# Altium schematic sheet 02.STM32F103VCT6.SchDoc
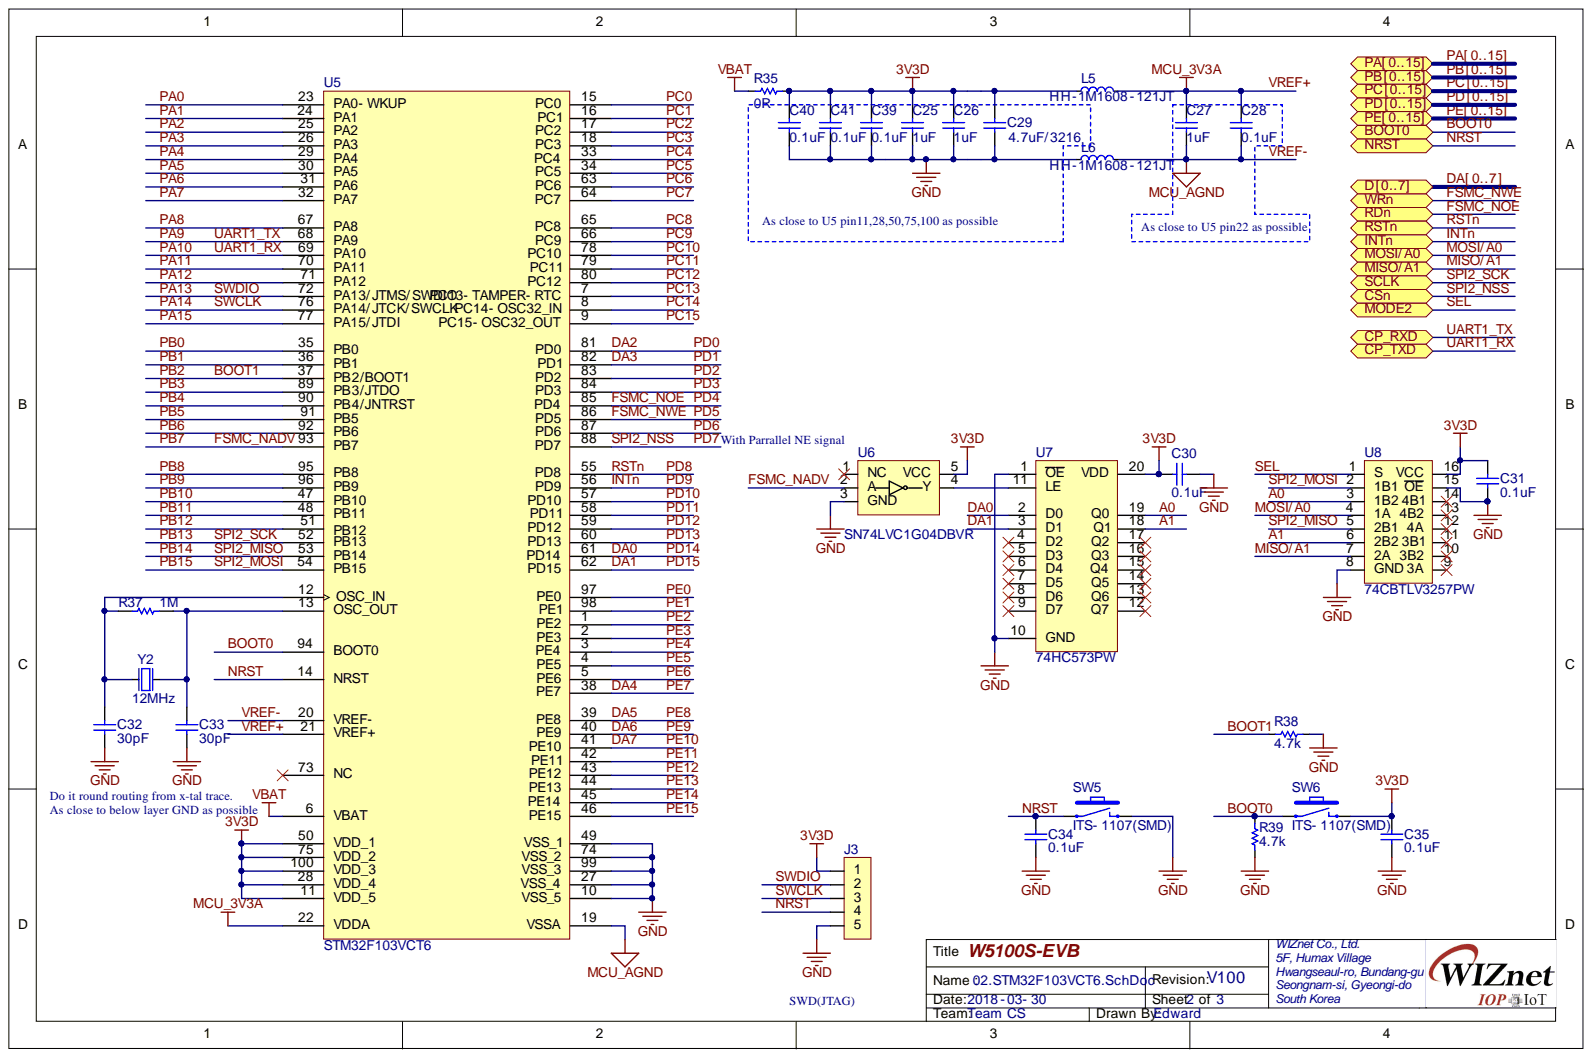
[diagram p0: 02.STM32F103VCT6.SchDoc]

## Parts
C25  1uF  [CAP-0603]
C26  1uF  [CAP-0603]
C27  1uF  [CAP-0603]
C28  0.1uF  [CAP-0603]
C29  4.7uF/3216  [CAP-1206]
C30  0.1uF  [CAP-0603]
C31  0.1uF  [CAP-0603]
C32  30pF  [CAP-0603]
C33  30pF  [CAP-0603]
C34  0.1uF  [CAP-0603]
C35  0.1uF  [CAP-0603]
C39  0.1uF  [CAP-0603]
C40  0.1uF  [CAP-0603]
C41  0.1uF  [CAP-0603]
J3  2.54mm 1x5 Pin Header DIP  [IDC-1X5]  (value not drawn)
L5  HH-1M1608-121JT  [IND-0603]
L6  HH-1M1608-121JT  [IND-0603]
R35  0R  [RES-0603]
R37  1M  [RES-0603]
R38  4.7k  [RES-0603]
R39  4.7k  [RES-0603]
SW5  ITS-1107(SMD)  [IT-1107(SMD)]
SW6  ITS-1107(SMD)  [IT-1107(SMD)]
U5  STM32F103VCT6  [LQFP100(0.5/16.0)]
U6  SN74LVC1G04DBVR  [SOT-23-5L]
U7  74HC573PW  [TSSOP20(0.65/6.4)]
U8  74CBTLV3257PW  [TSSOP16(0.65/6.4)]
Y2  12MHz  [OSC-82J0626]

## Ports
BOOT0, CP_RXD, CP_TXD, CSN, D0, D1, D2, D3, D4, D5, D6, D7, D[0..7], INTN, MISO/A1, MODE2, MOSI/A0, NRST, PA0, PA1, PA2, PA3, PA4, PA5, PA6, PA7, PA8, PA9, PA10, PA11, PA12, PA13, PA14, PA15, PA[0..15], PB0, PB1, PB2, PB3, PB4, PB5, PB6, PB7, PB8, PB9, PB10, PB11, PB12, PB13, PB14, PB15, PB[0..15], PC0, PC1, PC2, PC3, PC4, PC5, PC6, PC7, PC8, PC9, PC10, PC11, PC12, PC13, PC14, PC15, PC[0..15], PD0, PD1, PD2, PD3, PD4, PD5, PD6, PD7, PD8, PD9, PD10, PD11, PD12, PD13, PD14, PD15, PD[0..15], PE0, PE1, PE2, PE3, PE4, PE5, PE6, PE7, PE8, PE9, PE10, PE11, PE12, PE13, PE14, PE15, PE[0..15], RDN, RSTN, SCLK, WRN

## Nets
3V3D: C25.2 C26.2 C29.2 C30.2 C31.2 C35.2 C39.2 C40.2 C41.2 J3.1 L5.1 R35.2 SW6.2 U5.11(VDD_5) U5.28(VDD_4) U5.50(VDD_1) U5.75(VDD_2) U5.100(VDD_3) U6.5(VCC) U7.20(VDD) U8.16(VCC)
GND: C25.1 C26.1 C29.1 C30.1 C31.1 C32.1 C33.1 C34.1 C35.1 C39.1 C40.1 C41.1 J3.5 L6.1 R38.1 R39.2 SW5.2 U5.10(VSS_5) U5.27(VSS_4) U5.49(VSS_1) U5.74(VSS_2) U5.99(VSS_3) U6.3(GND) U7.1(OE) U7.10(GND) U8.8(GND) U8.15(OE) Y2.2 Y2.4
VBAT: R35.1 U5.6(VBAT)
A0: U7.19(Q0) U8.3(1B2)
A1: U7.18(Q1) U8.6(2B2)
BOOT0: R39.1 SW6.1 U5.94(BOOT0) ; port BOOT0
DA0: U5.61(PD14) U7.2(D0) ; port D[0..7], port PD[0..15]  [also labelled DA]
DA1: U5.62(PD15) U7.3(D1) ; port D[0..7], port PD[0..15]  [also labelled DA]
DA2: U5.81(PD0) ; port D[0..7], port PD[0..15]  [also labelled DA]
DA3: U5.82(PD1) ; port D[0..7], port PD[0..15]  [also labelled DA]
DA4: U5.38(PE7) ; port D[0..7], port PE[0..15]  [also labelled DA]
DA5: U5.39(PE8) ; port D[0..7], port PE[0..15]  [also labelled DA]
DA6: U5.40(PE9) ; port D[0..7], port PE[0..15]  [also labelled DA]
DA7: U5.41(PE10) ; port D[0..7], port PE[0..15]  [also labelled DA]
MISO/A1: U8.7(2A) ; port MISO/A1
MOSI/A0: U8.4(1A) ; port MOSI/A0
NRST: C34.2 J3.4 SW5.1 U5.14(NRST) ; port NRST
PA0: U5.23(PA0-_WKUP) ; port PA[0..15]  [also labelled PA]
PA1: U5.24(PA1) ; port PA[0..15]  [also labelled PA]
PA2: U5.25(PA2) ; port PA[0..15]  [also labelled PA]
PA3: U5.26(PA3) ; port PA[0..15]  [also labelled PA]
PA4: U5.29(PA4) ; port PA[0..15]  [also labelled PA]
PA5: U5.30(PA5) ; port PA[0..15]  [also labelled PA]
PA6: U5.31(PA6) ; port PA[0..15]  [also labelled PA]
PA7: U5.32(PA7) ; port PA[0..15]  [also labelled PA]
PA8: U5.67(PA8) ; port PA[0..15]  [also labelled PA]
PA9: U5.68(PA9) ; port CP_RXD, port PA[0..15]  [also labelled PA]
PA10: U5.69(PA10) ; port CP_TXD, port PA[0..15]  [also labelled PA]
PA11: U5.70(PA11) ; port PA[0..15]  [also labelled PA]
PA12: U5.71(PA12) ; port PA[0..15]  [also labelled PA]
PA13: J3.2 U5.72(PA13/_JTMS/_SWDIO) ; port PA[0..15]  [also labelled PA]
PA14: J3.3 U5.76(PA14/_JTCK/_SWCLK) ; port PA[0..15]  [also labelled PA]
PA15: U5.77(PA15/_JTDI) ; port PA[0..15]  [also labelled PA]
PB0: U5.35(PB0) ; port PB[0..15]  [also labelled PB]
PB1: U5.36(PB1) ; port PB[0..15]  [also labelled PB]
PB3: U5.89(PB3/JTDO) ; port PB[0..15]  [also labelled PB]
PB4: U5.90(PB4/JNTRST) ; port PB[0..15]  [also labelled PB]
PB5: U5.91(PB5) ; port PB[0..15]  [also labelled PB]
PB6: U5.92(PB6) ; port PB[0..15]  [also labelled PB]
PB8: U5.95(PB8) ; port PB[0..15]  [also labelled PB]
PB9: U5.96(PB9) ; port PB[0..15]  [also labelled PB]
PB10: U5.47(PB10) ; port PB[0..15]  [also labelled PB]
PB11: U5.48(PB11) ; port PB[0..15]  [also labelled PB]
PB12: U5.51(PB12) ; port PB[0..15]  [also labelled PB]
PB13: U5.52(PB13) ; port PB[0..15], port SCLK  [also labelled PB]
PB14: U5.53(PB14) U8.5(2B1) ; port PB[0..15]  [also labelled PB]
PB15: U5.54(PB15) U8.2(1B1) ; port PB[0..15]  [also labelled PB]
PC0: U5.15(PC0) ; port PC[0..15]  [also labelled PC]
PC1: U5.16(PC1) ; port PC[0..15]  [also labelled PC]
PC2: U5.17(PC2) ; port PC[0..15]  [also labelled PC]
PC3: U5.18(PC3) ; port PC[0..15]  [also labelled PC]
PC4: U5.33(PC4) ; port PC[0..15]  [also labelled PC]
PC5: U5.34(PC5) ; port PC[0..15]  [also labelled PC]
PC6: U5.63(PC6) ; port PC[0..15]  [also labelled PC]
PC7: U5.64(PC7) ; port PC[0..15]  [also labelled PC]
PC8: U5.65(PC8) ; port PC[0..15]  [also labelled PC]
PC9: U5.66(PC9) ; port PC[0..15]  [also labelled PC]
PC10: U5.78(PC10) ; port PC[0..15]  [also labelled PC]
PC11: U5.79(PC11) ; port PC[0..15]  [also labelled PC]
PC12: U5.80(PC12) ; port PC[0..15]  [also labelled PC]
PC13: U5.7(PC13-_TAMPER-_RTC) ; port PC[0..15]  [also labelled PC]
PC14: U5.8(PC14-_OSC32_IN) ; port PC[0..15]  [also labelled PC]
PC15: U5.9(PC15-_OSC32_OUT) ; port PC[0..15]  [also labelled PC]
PD2: U5.83(PD2) ; port PD[0..15]  [also labelled PD]
PD3: U5.84(PD3) ; port PD[0..15]  [also labelled PD]
PD6: U5.87(PD6) ; port PD[0..15]  [also labelled PD]
PD7: U5.88(PD7) ; port PD[0..15]  [also labelled PD]
PD8: U5.55(PD8) ; port PD[0..15]  [also labelled PD]
PD10: U5.57(PD10) ; port PD[0..15]  [also labelled PD]
PD11: U5.58(PD11) ; port PD[0..15]  [also labelled PD]
PD12: U5.59(PD12) ; port PD[0..15]  [also labelled PD]
PD13: U5.60(PD13) ; port PD[0..15]  [also labelled PD]
PE0: U5.97(PE0) ; port PE[0..15]  [also labelled PE]
PE1: U5.98(PE1) ; port PE[0..15]  [also labelled PE]
PE2: U5.1(PE2) ; port PE[0..15]  [also labelled PE]
PE3: U5.2(PE3) ; port PE[0..15]  [also labelled PE]
PE4: U5.3(PE4) ; port PE[0..15]  [also labelled PE]
PE5: U5.4(PE5) ; port PE[0..15]  [also labelled PE]
PE6: U5.5(PE6) ; port PE[0..15]  [also labelled PE]
PE11: U5.42(PE11) ; port PE[0..15]  [also labelled PE]
PE12: U5.43(PE12) ; port PE[0..15]  [also labelled PE]
PE13: U5.44(PE13) ; port PE[0..15]  [also labelled PE]
PE14: U5.45(PE14) ; port PE[0..15]  [also labelled PE]
PE15: U5.46(PE15) ; port PE[0..15]  [also labelled PE]
SEL: U8.1(S) ; port MODE2
VREF+: C27.2 C28.2 L5.2 U5.21(VREF+) U5.22(VDDA)
VREF-: C27.1 C28.1 L6.2 U5.19(VSSA) U5.20(VREF-)
NetC32_2: C32.2 R37.1 U5.12(OSC_IN) Y2.1
NetC33_2: C33.2 R37.2 U5.13(OSC_OUT) Y2.3
NetU6_4: U6.4(Y) U7.11(LE)

Not connected: R38 2; U5 37(PB2/BOOT1) 56(PD9) 73(NC) 85(PD4) 86(PD5) 93(PB7); U6 1(NC) 2(A); U7 4(D2) 5(D3) 6(D4) 7(D5) 8(D6) 9(D7) 12(Q7) 13(Q6) 14(Q5) 15(Q4) 16(Q3) 17(Q2); U8 9(3A) 10(3B2) 11(3B1) 12(4A) 13(4B2) 14(4B1)

## Library data of the parts
C25-C28 C30-C35 C39-C41 = CAP-0603: Ceramic CAP 0603(1608); Component Kind: Standard; Library Name: Scott_lib.IntLib
C29 = CAP-1206: Ceramic CAP 1206(3216); Component Kind: Standard; Library Name: Scott_lib.IntLib
L5 L6 = IND-0603: Inductor 0603(1608); Component Kind: Standard; Library Name: Scott_lib.IntLib
R35 R38 R39 = RES-0603: RES0603; Component Kind: Standard; Library Name: Scott_lib.IntLib
R37 = RES-0603: Component Kind: Standard; Description: RES-0603; Library Name: Scott_lib.IntLib
SW5 SW6 = ITS-1107(SMD): TACT Switch (SMD); Component Kind: Standard; Library Name: Scott_lib.IntLib
U5 = STM32F103VBT6: STM32 ARM-based 32-bit MCU with 64 Kbytes Flash, 100-pin LQFP, Industrial Temperature; Component Kind: Standard; ComponentLink1Description: Manufacturer Link; ComponentLink1URL: www.st.com/stm32; ComponentLink2Description: Datasheet; ComponentLink2URL: http://www.st.com/stonline/products/literature/ds/13587.pdf; DatasheetVersion: rev6, Mar-2008; Library Name: ST Microcontroller 32-Bit STM32.IntLib; PackageDescription: 100-Pin Low-Profile Quad Flat Pack, 14 x 14 mm Body, 0.5 mm Pitch (LQFP100); PackageReference: LQFP100; PackageVersion: Mar-2008; Published: 30-May-2008; Publisher: Altium Limited
U6 = SN74LVC1G04DBVR: Single Inverter Gate; Component Kind: Standard; Library Name: Scott_lib.IntLib
U7 = MC74HCT573ADTR2: Octal 3-State Non-Inverting Transparent Latch with LSTTL-Compatible Inputs; Component Kind: Standard; ComponentLink1Description: Manufacturer Link; ComponentLink1URL: http://www.onsemi.com/; ComponentLink2Description: Datasheet; ComponentLink2URL: http://www.onsemi.com/pub/Collateral/MC74HCT573A-D.PDF; DatasheetVersion: Mar-2000; LatestRevisionDate: 12-Dec-2006; LatestRevisionNote: IPC-7351 Footprint Added.; Library Name: ON Semi Logic Latch.IntLib; PackageDescription: 20-Pin Thin Small Outline Package 0.65 mm Pitch; PackageReference: 948E-02; PackageVersion: Mar-2000; Published: 15-Apr-2002; Signal Integrity: MC74HCT573ADTR2; Publisher: Altium Limited
U8 = 74CBTLV3257PW: Quad 1-of-2 multiplexer/demultiplexer; Component Kind: Standard; Library Name: Scott_lib.IntLib
Y2 = OSC-TZ1067B-12MHz: X-tal 12MHz,3.2x2.5 SMD, CL=20pF; Component Kind: Standard; Library Name: Scott_lib.IntLib
J3 = 2.54mm 1x5 Pin Header DIP: Component Kind: Standard; Description: 2.54mm 1x5 Pin Header DIP; Library Name: Scott_lib.IntLib
